# Altium schematic sheet measurement.SchDoc
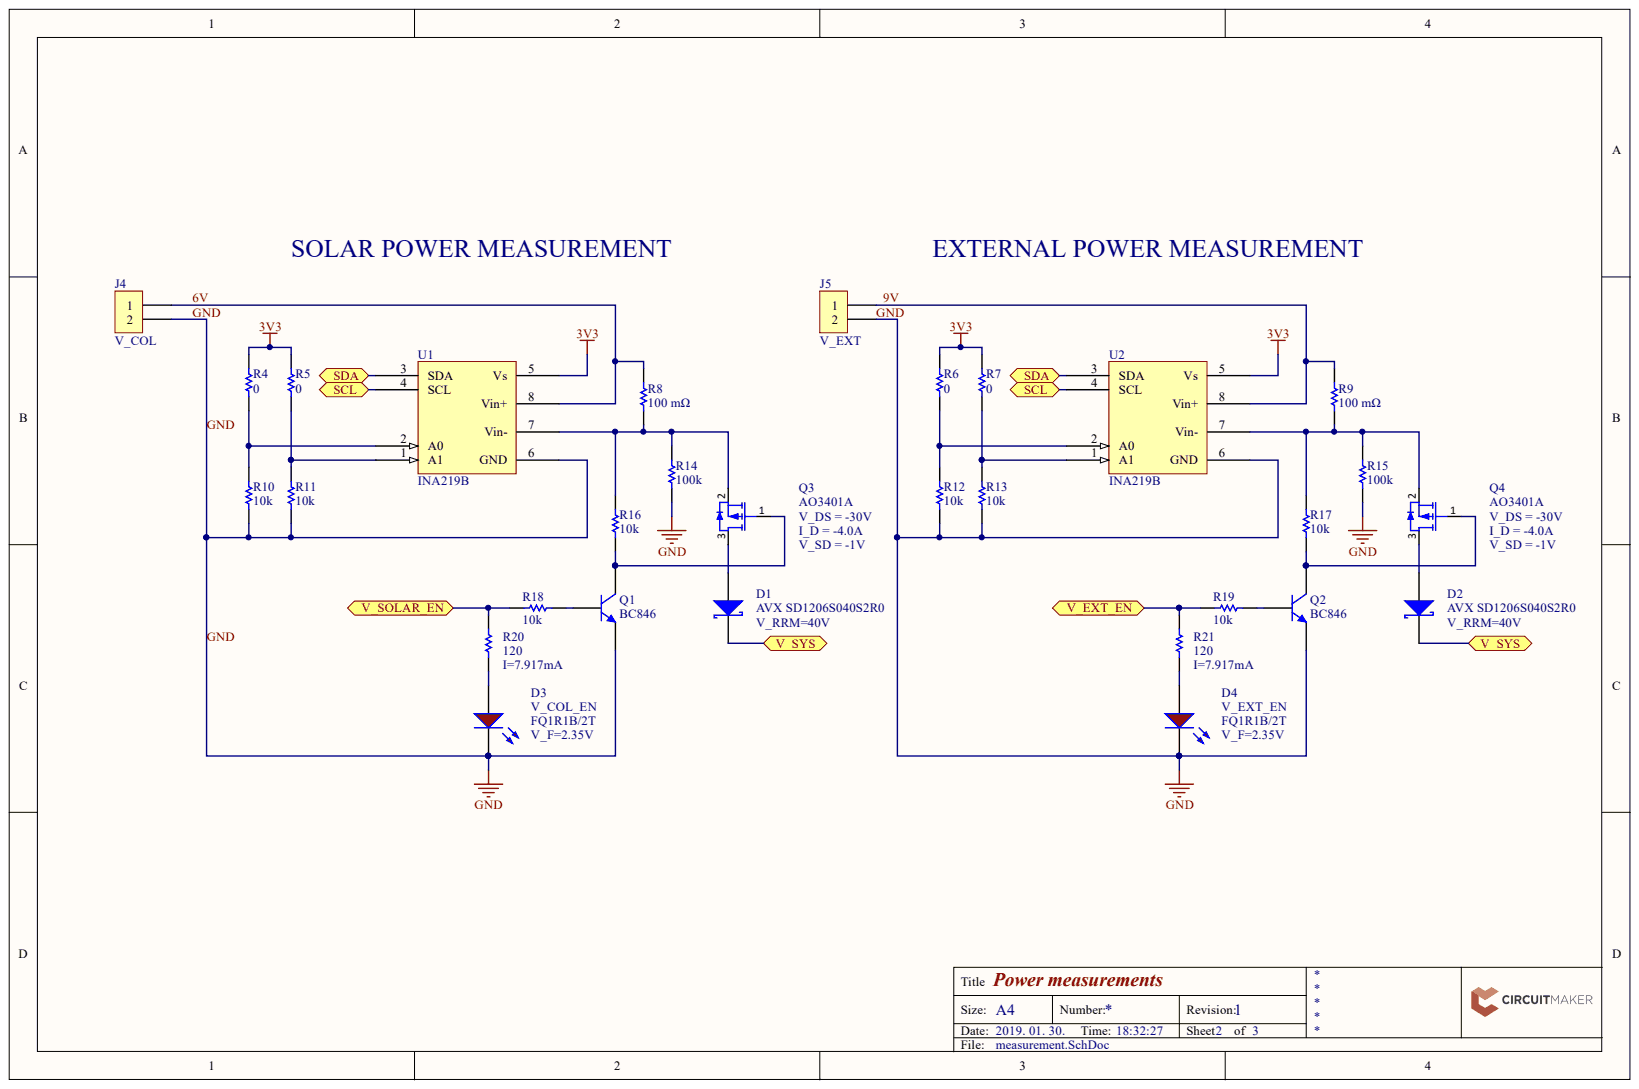
[diagram p0: measurement.SchDoc]

## Parts
D1  AVX SD1206S040S2R0  [BOUR-CD1206-S01575-2_V]
D2  AVX SD1206S040S2R0  [BOUR-CD1206-S01575-2_V]
D3  V_COL_EN  [PCB-j4n23iwtpnxz235ppza8-1]
D4  V_EXT_EN  [PCB-j4n23iwtpnxz235ppza8-1]
J4  V_COL  [393570002]
J5  V_EXT  [393570002]
Q1  BC846  [PCB-9tehksr1lkz5ye0q0lem-1]
Q2  BC846  [PCB-9tehksr1lkz5ye0q0lem-1]
Q3  AO3401A  [PCB-832298-1]
Q4  AO3401A  [PCB-832298-1]
R4  0  [ERJ-8ENF1001V]
R5  0  [ERJ-8ENF1001V]
R6  0  [ERJ-8ENF1001V]
R7  0  [ERJ-8ENF1001V]
R8  100 mΩ  [RESC6432X07L]
R9  100 mΩ  [RESC6432X07L]
R10  10k  [ERJ-8ENF1001V]
R11  10k  [ERJ-8ENF1001V]
R12  10k  [ERJ-8ENF1001V]
R13  10k  [ERJ-8ENF1001V]
R14  100k  [ERJ-8ENF1001V]
R15  100k  [ERJ-8ENF1001V]
R16  10k  [ERJ-8ENF1001V]
R17  10k  [ERJ-8ENF1001V]
R18  10k  [ERJ-8ENF1001V]
R19  10k  [ERJ-8ENF1001V]
R20  120  [ERJ-8ENF1001V]
R21  120  [ERJ-8ENF1001V]
U1  INA219B  [PCB-1422392-1]
U2  INA219B  [PCB-1422392-1]

## Ports
SCL, SDA, V_EXT_EN, V_SOLAR_EN, V_SYS

## Nets
3V3: R4.2 R5.2 R6.2 R7.2 U1.5(Vs) U2.5(Vs)
6V: J4.1 R8.2 U1.8(Vin+)
9V: J5.1 R9.2 U2.8(Vin+)
GND: D3.2 D4.2 J4.2 J5.2 Q1.2 Q2.2 R10.1 R11.1 R12.1 R13.1 R14.2 R15.2 U1.6(GND) U2.6(GND)
SCL: U1.4(SCL) U2.4(SCL) ; port SCL
SDA: U1.3(SDA) U2.3(SDA) ; port SDA
V_EXT_EN: R19.1 R21.2 ; port V_EXT_EN
V_SOLAR_EN: R18.1 R20.2 ; port V_SOLAR_EN
V_SYS: D1.1 D2.1 ; port V_SYS
NetD1_2: D1.2 Q3.3
NetD2_2: D2.2 Q4.3
NetD3_1: D3.1 R20.1
NetD4_1: D4.1 R21.1
NetQ1_1: Q1.1 R18.2
NetQ1_3: Q1.3 Q3.1 R16.2
NetQ2_1: Q2.1 R19.2
NetQ2_3: Q2.3 Q4.1 R17.2
NetQ3_2: Q3.2 R8.1 R14.1 R16.1 U1.7(Vin-)
NetQ4_2: Q4.2 R9.1 R15.1 R17.1 U2.7(Vin-)
NetR4_1: R4.1 R10.2 U1.2(A0)
NetR5_1: R5.1 R11.2 U1.1(A1)
NetR6_1: R6.1 R12.2 U2.2(A0)
NetR7_1: R7.1 R13.2 U2.1(A1)

## Library data of the parts
D1 D2 = CMP-0c92c4e4444fd355-1: Small Signal Schottky Diode, Single, 40 V, 2 A, 500 mV, 40 A, 125 C; : V_RRM=40V; Component Kind: Standard; Library Name: CommunityVault; Manufacturer Part Number: SD1206S040S2R0; Number of Pins: 2; OctopartID: 0c92c4e4444fd355; Octopart URL: http://octopart.com/sd1206s040s2r0-avx-24931771; Packaging: Cut Tape (CT); REACH SVHC Compliance: No SVHC; RoHS: Compliant
D3 D4 = CMP-50699963429b8fae-3: Red 3.2 x 1.6 mm 1206 160° Water Clear 6 mcd 2.2 V PCB Surface Mount LED; : V_F=2.35V; : FQ1R1B/2T; Component Kind: Standard; Library Name: CommunityVault; Size-Length: 3.20 mm
J4 J5 = CMP-b56f7cee77fd6aa8-1: 3.50mm (.138") Pitch Beau Eurostyle Fixed Mount PCB Terminal Block, 2 Circuits; Current Rating: 65.0 A; Number of Positions: 2; Component Kind: Standard; Flammability Rating: UL 94 V-0; Library Name: CommunityVault; Size-Height: 8.51 mm
Q1 Q2 = CMP-58ad911ac680876a-1: BC846 Series 65 V 100 mA SMT NPN General-Purpose Transistor - SOT-23; Manufacturer Part Number: BC846,215; Lead-Free Status: Lead Free; Polarity: NPN, N-Channel; Component Kind: Standard; Library Name: CommunityVault; Lifecycle Status: Active; Mounting Style: Surface Mount; Number of Pins: 3; OctopartID: 58ad911ac680876a; Octopart URL: http://octopart.com/bc846%2C215-nxp+semiconductors-12434251; Packaging: Tape & Reel (TR), Cut Tape (CT); REACH SVHC Compliance: No SVHC; RoHS: Compliant
Q3 Q4 = CMP-832298-6: MOSFET P-CH 30V 4A SOT23; diode forward voltage: V_SD = -1V; drain current: I_D = -4.0A; drain source voltage: V_DS = -30V; Lead-Free Status: Lead Free; Component Kind: Standard; Library Name: CommunityVault; Manufacturer Part Number: AO3401A; OctopartID: b3d67a74a8336c11; Octopart Url: https://octopart.com/ao3401a-alpha+%26+omega+semiconductor-11026051; RoHS: Compliant
R4-R7 R10-R21 = CMP-3663831-4: Res Thick Film 3.2 x 1.6 mm 1K Ohm 1% 0.25W(1/4W) 100ppm/ C Molded SMD Punched Carrier T/R; Power Rating: 250 mW; Resistance: 1.00 kΩ; Component Kind: Standard; Library Name: CommunityVault; Lifecycle Status: Not Listed by Manufacturer; Manufacturer Part Number: ERJ-8ENF1001V; Mounting Style: Surface Mount; Number of Pins: 2; OctopartID: 229be8dcb721fd5c; Octopart URL: http://octopart.com/erj-8enf1001v-panasonic-55423196; Packaging: Cut Tape (CT), Tape & Reel (TR); Resistance Tolerance: ±1%; RoHS: Compliant; Size-Height: 600 µm; Size-Length: 2.00 mm; Size-Width: 1.60 mm
  R20 R21: : I=7.917mA
R8 R9 = CMP-7ee6b6ce304aa121-2: Res Thick Film 2512 0.1 Ohm 1% 1W ±100ppm/C Epoxy Pad SMD Automotive T/R; Component Kind: Standard; Library Name: CommunityVault; OctopartID: 7ee6b6ce304aa121; Octopart URL: http://octopart.com/pt2512fk-070r1l-yageo-19033034; Packaging: Tape & Reel (7 in.); Resistance: 100 mΩ; Resistance Tolerance: ±1%; RoHS: Compliant
U1 U2 = CMP-1422392-3: IC MONITOR PWR/CURR BIDIR 8-SOIC; Bandwidth: 11000.0 Hz; Case/Package: SOIC; Component Kind: Standard; Library Name: CommunityVault; Lifecycle Status: Active; OctopartID: 5d9b95b1e956825c
